# Altium schematic sheet VoltageRegulator.SchDoc(VoltageRegulator)
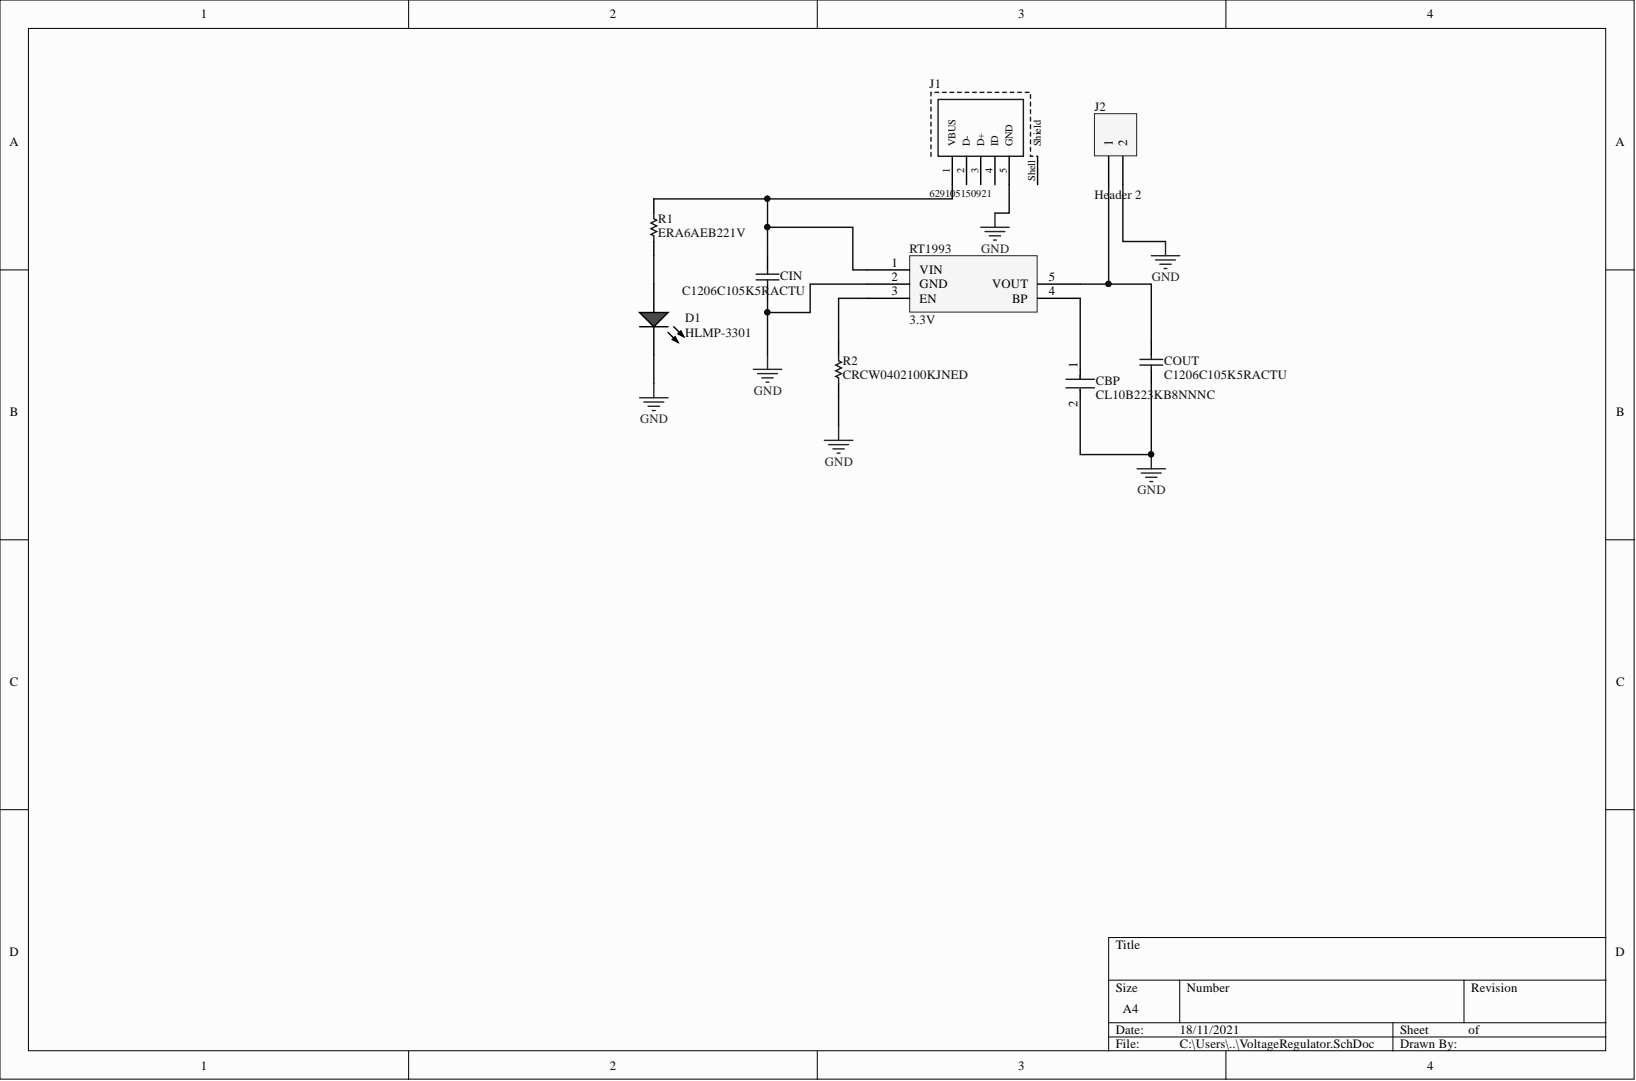
[diagram p0: VoltageRegulator.SchDoc]

## Parts
CBP  CL10B223KB8NNNC  [FP-CL10-IPC_C]
CIN  C1206C105K5RACTU  [CAPC3216X110X50LL20T25]
COUT  C1206C105K5RACTU  [CAPC3216X110X50LL20T25]
D1  HLMP-3301  [AVAG-HLMP-3301-2]
J1  629105150921  [629105150921]
J2  Header 2  [HDR1X2]
R1  ERA6AEB221V  [RESC2013X60X40NL20T25]
R2  CRCW0402100KJNED  [RESC1005X40X25LL05T05]
RT1993  3.3V  [SOT85P279X130-5N]

## Nets
GND: CBP.2 CIN.1 COUT.1 D1.1 J1.5(GND) J2.2 R2.1 RT1993.2(GND)
NetCBP_1: CBP.1 RT1993.4(BP)
NetCIN_2: CIN.2 J1.1(VBUS) R1.2 RT1993.1(VIN)
NetCOUT_2: COUT.2 J2.1 RT1993.5(VOUT)
NetD1_2: D1.2 R1.1
NetR2_2: R2.2 RT1993.3(EN)

Not connected: J1 2(D-) 3(D+) 4(ID) Shell(Shield)

## Library data of the parts
CBP = CMP-13271-001437-1: Cap Ceramic 22nF 50V X7R ±10% Pad SMD 0603 +125°C T/R; Capacitance: 22nF; Case Code (Imperial): 0603; Case Code (Metric): 1608; Case/Package: 0603; Component Kind: Standard; Contact Plating: Tin; Depth: 0.8mm; Dielectric: X7R; Height: 0.8mm; Lead Free: Lead Free; Length: 1.6mm; Library Name: Altium Content Vault; Material: Ceramic; Max Operating Temperature: 125°C; Min Operating Temperature: -55°C; Mount: Surface Mount; Package Quantity: 1; Packaging: Tape and Reel; Radiation Hardening: No; Thickness: 0.035inch; Tolerance: 10%; Voltage: 50V; Voltage Rating: 50V; Voltage Rating (DC): 50V; Width: 0.031inch
CIN COUT = CMP-2000-06011-1: Chip Capacitor, 1 uF, +/- 10%, 50 V, -55 to 125 degC, 1206 (3216 Metric), RoHS, Tape and Reel; Brand: Kemet; Capacitance: 1uF; Capacitance - nF: 1000nF; Case Height: 1mm; Case Length: 3.2mm; Case Width: 1.6mm; Class: Class1; Dielectric: X7R; Temperature Coefficient / Code: +/-15%; Voltage Rating: 50V; : C1206C105K5RAC7800; Case Code - in: 1206; Case Code - mm: 3216; Component Kind: Standard; Datasheet URL: http://www.kemet.com/docfinder?Partnumber=C1206C105K5RACTU; Datasheet Version: 02/2016; Dimensions: 1.6mmWx3.2mmLx1mmH; Factory Pack Quantity: 2500; Library Name: Altium Content Vault; Manufacturer: Kemet; Manufacturer URL: http://www.kemet.com/; Maximum Operating Temperature: +125C; Minimum Operating Temperature: -55C; Mounting Technology: SurfaceMount; Operating Temperature Range: -55Cto+125C; Package / Case: 1206(3216metric); Package Description: 2-Pin Surface Mount Device, Body 3.2 x 1.6 mm; Package Reference: 1206; Packaging: Reel; Product: GeneralTypeMLCCs; Product Category: MultilayerCeramicCapacitorsMLCC-SMD/SMT; RoHS: Details; Series: C1206C; Termination Style: SMD/SMT; Tolerance: 10%; Type: SMDMLCCX7R6.3-250VDCCommericalGrade; Voltage Rating DC: 50V
D1 = CMP-2000-06411-1: T-1 3/4 (5 mm) Diffused LED Lamp, 1.9 V, -40 to 100 degC, 2-Pin THD, RoHS, Bulk; Manufacturer: Avago; Chip Material: GaP; DELETED: Compliant; Dominant Wave Length: 626nm; Emitting Color: Red; Forward Current: 25mA; Forward Voltage: 2.4V; High Brightness: No; Lens Type: ColoredDiffused; Lum Intensity: 5.4mcd; Main Category: StandardLED; Number of  Elements: 1; Peak Wavelength: 635nm; Power Dissipation: 85W; Product Depth (mm): 6.1mm; Product Height (mm): 9.19mm; Reverse Voltage: 5V; Shape Type: Circular; Test Current (It): 10mA; Type: Uni-Color; Viewing Angle: 60deg; Component Kind: Standard; Datasheet URL: http://www.avagotech.com/docs/AV02-1558EN; Datasheet Version: 03/2015; Lens Dimensions: 5.08x5.08x8.3mm; Library Name: Altium Content Vault; Luminous Intensity: 5.4mcd; Manufacturer URL: http://www.avagotech.com/; Mounting: ThroughHole; Mounting Technology: ThroughHole; Operating Temp Range: -40Cto100C; Operating Temperature Classification: Industrial; Package Description: 2-Pin Through Hole LED, Dia 5.85 mm; Package Reference: HLMP-3301; Package Type: T-13/4; Packaging: TapeandReel; Pin Count: 2; Product Length (mm): 6.1mm; Rad Hardened: No
J1 = CMP-1502-03249-1: Micro USB 2.0 Type AB Receptacle WR-COM, Horizontal, SMT; Manufacturer: Wurth Elektronik; Contact Resistance: 30mOhm; Rated Current: 1.8A; Working Voltage: 30VAC; Component Kind: Standard; Connector Type: MicroUSB2.0TypeAB; Datasheet URL: https://katalog.we-online.de/em/datasheet/629105150921.pdf; Gender: Receptacle; Library Name: Altium Content Vault; Manufacturer URL: http://www.we-online.de; Mounting Technology: SMT; Operating Temperature: -40to85degC; Orientation: Horizontal; Package Reference: 629105150921; Packaging: TapeandReel; Part Number: 629105150921; Publisher: WurthElektronik
J2 = Header 2: Header, 2-Pin; Component Kind: Standard; LatestRevisionDate: 17-Jul-2002; LatestRevisionNote: Re-released for DXP Platform.; Library Name: Miscellaneous Connectors.IntLib; Signal Integrity: Connector; Publisher: Altium Limited
R1 = CMP-2001-00612-1: Manufacturer: Panasonic; Component Kind: Standard; Library Name: Altium Content Vault; Part Number: ERA6AEB221V
R2 = CMP-2002-08125-1: Manufacturer: Vishay Dale; Component Kind: Standard; Library Name: Altium Content Vault; Part Number: CRCW0402100KJNED
RT1993 = KXEC-629051: IC REG LINEAR 3.3V 300MA SOT23-5; Component Kind: Standard; Library Name: RT1993-33GB.SchLib
